# Altium schematic sheet Sheet2.SchDoc(Sheet2)
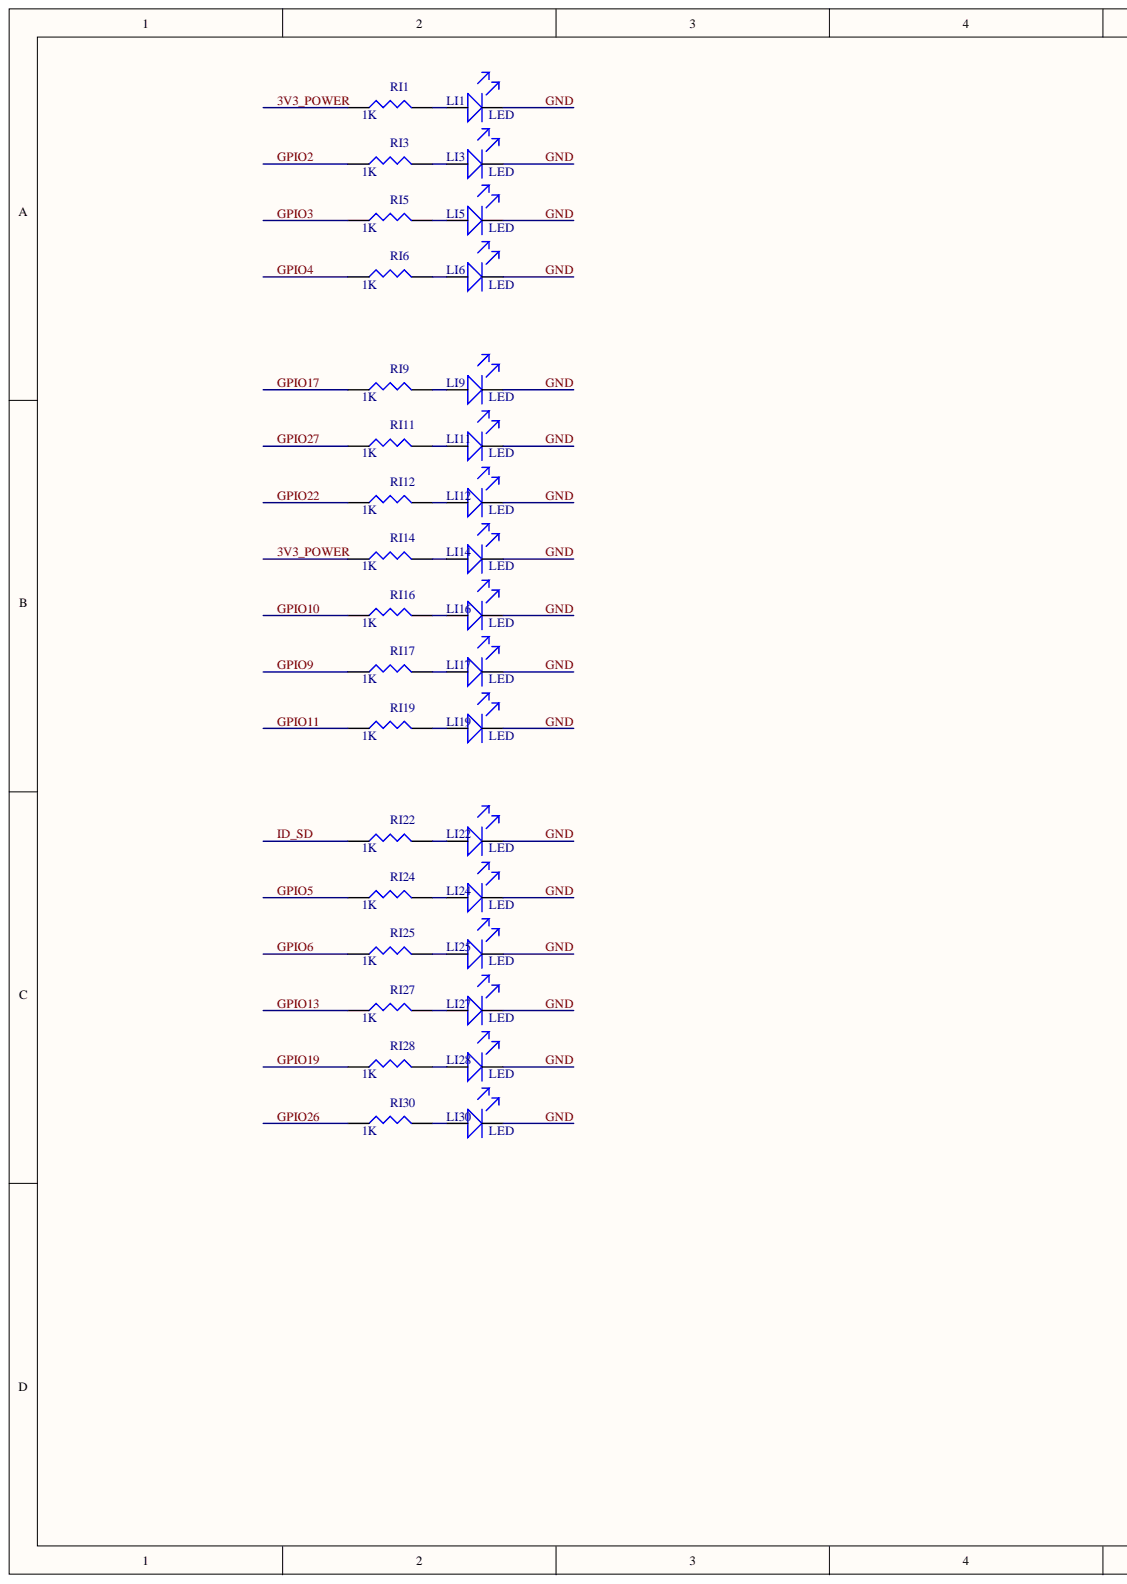
[diagram p0: Sheet2.SchDoc, left]
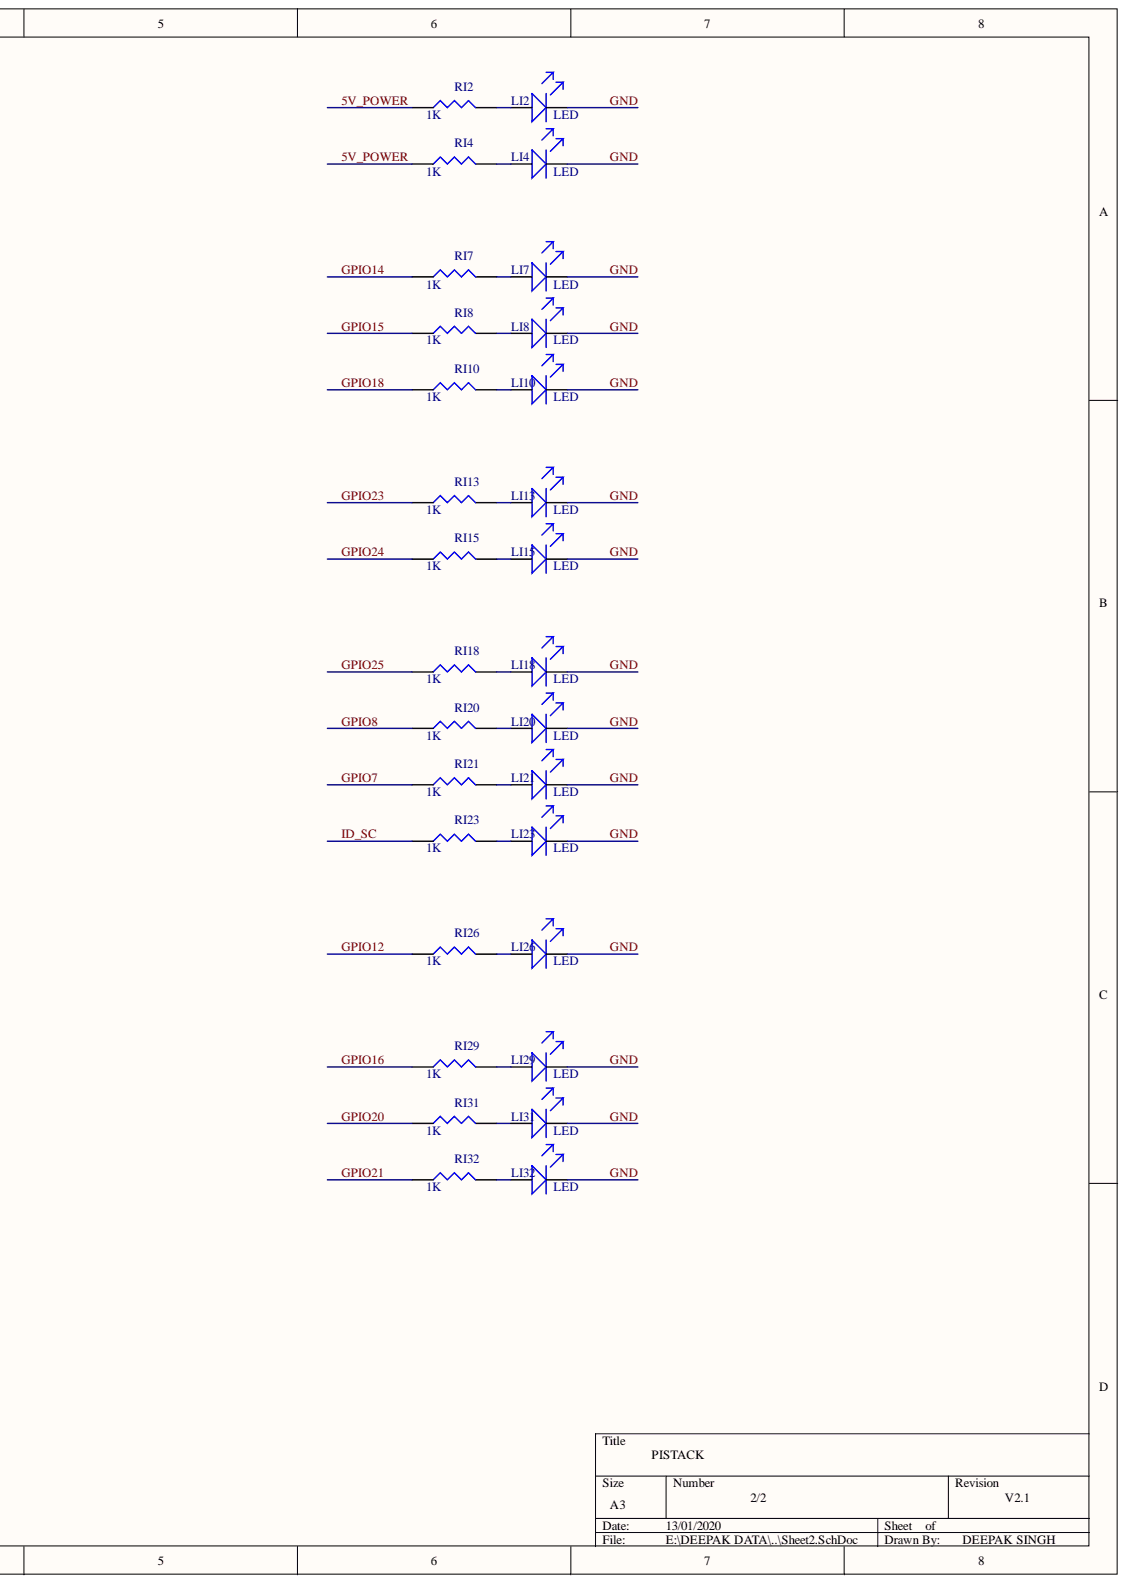
[diagram p1: Sheet2.SchDoc, right]

## Parts
LI1  LED  [LED_0603_neg]
LI2  LED  [LED_0603_neg]
LI3  LED  [LED_0603_neg]
LI4  LED  [LED_0603_neg]
LI5  LED  [LED_0603_neg]
LI6  LED  [LED_0603_neg]
LI7  LED  [LED_0603_neg]
LI8  LED  [LED_0603_neg]
LI9  LED  [LED_0603_neg]
LI10  LED  [LED_0603_neg]
LI11  LED  [LED_0603_neg]
LI12  LED  [LED_0603_neg]
LI13  LED  [LED_0603_neg]
LI14  LED  [LED_0603_neg]
LI15  LED  [LED_0603_neg]
LI16  LED  [LED_0603_neg]
LI17  LED  [LED_0603_neg]
LI18  LED  [LED_0603_neg]
LI19  LED  [LED_0603_neg]
LI20  LED  [LED_0603_neg]
LI21  LED  [LED_0603_neg]
LI22  LED  [LED_0603_neg]
LI23  LED  [LED_0603_neg]
LI24  LED  [LED_0603_neg]
LI25  LED  [LED_0603_neg]
LI26  LED  [LED_0603_neg]
LI27  LED  [LED_0603_neg]
LI28  LED  [LED_0603_neg]
LI29  LED  [LED_0603_neg]
LI30  LED  [LED_0603_neg]
LI31  LED  [LED_0603_neg]
LI32  LED  [LED_0603_neg]
RI1  1K  [0603]
RI2  1K  [0603]
RI3  1K  [0603]
RI4  1K  [0603]
RI5  1K  [0603]
RI6  1K  [0603]
RI7  1K  [0603]
RI8  1K  [0603]
RI9  1K  [0603]
RI10  1K  [0603]
RI11  1K  [0603]
RI12  1K  [0603]
RI13  1K  [0603]
RI14  1K  [0603]
RI15  1K  [0603]
RI16  1K  [0603]
RI17  1K  [0603]
RI18  1K  [0603]
RI19  1K  [0603]
RI20  1K  [0603]
RI21  1K  [0603]
RI22  1K  [0603]
RI23  1K  [0603]
RI24  1K  [0603]
RI25  1K  [0603]
RI26  1K  [0603]
RI27  1K  [0603]
RI28  1K  [0603]
RI29  1K  [0603]
RI30  1K  [0603]
RI31  1K  [0603]
RI32  1K  [0603]

## Nets
3V3_POWER: RI1.1 RI14.1
5V_POWER: RI2.1 RI4.1
GND: LI1.K LI2.K LI3.K LI4.K LI5.K LI6.K LI7.K LI8.K LI9.K LI10.K LI11.K LI12.K LI13.K LI14.K LI15.K LI16.K LI17.K LI18.K LI19.K LI20.K LI21.K LI22.K LI23.K LI24.K LI25.K LI26.K LI27.K LI28.K LI29.K LI30.K LI31.K LI32.K
GPIO2: RI3.1
GPIO3: RI5.1
GPIO4: RI6.1
GPIO5: RI24.1
GPIO6: RI25.1
GPIO7: RI21.1
GPIO8: RI20.1
GPIO9: RI17.1
GPIO10: RI16.1
GPIO11: RI19.1
GPIO12: RI26.1
GPIO13: RI27.1
GPIO14: RI7.1
GPIO15: RI8.1
GPIO16: RI29.1
GPIO17: RI9.1
GPIO18: RI10.1
GPIO19: RI28.1
GPIO20: RI31.1
GPIO21: RI32.1
GPIO22: RI12.1
GPIO23: RI13.1
GPIO24: RI15.1
GPIO25: RI18.1
GPIO26: RI30.1
GPIO27: RI11.1
ID_SC: RI23.1
ID_SD: RI22.1
NetLI1_A: LI1.A RI1.2
NetLI2_A: LI2.A RI2.2
NetLI3_A: LI3.A RI3.2
NetLI4_A: LI4.A RI4.2
NetLI5_A: LI5.A RI5.2
NetLI6_A: LI6.A RI6.2
NetLI7_A: LI7.A RI7.2
NetLI8_A: LI8.A RI8.2
NetLI9_A: LI9.A RI9.2
NetLI10_A: LI10.A RI10.2
NetLI11_A: LI11.A RI11.2
NetLI12_A: LI12.A RI12.2
NetLI13_A: LI13.A RI13.2
NetLI14_A: LI14.A RI14.2
NetLI15_A: LI15.A RI15.2
NetLI16_A: LI16.A RI16.2
NetLI17_A: LI17.A RI17.2
NetLI18_A: LI18.A RI18.2
NetLI19_A: LI19.A RI19.2
NetLI20_A: LI20.A RI20.2
NetLI21_A: LI21.A RI21.2
NetLI22_A: LI22.A RI22.2
NetLI23_A: LI23.A RI23.2
NetLI24_A: LI24.A RI24.2
NetLI25_A: LI25.A RI25.2
NetLI26_A: LI26.A RI26.2
NetLI27_A: LI27.A RI27.2
NetLI28_A: LI28.A RI28.2
NetLI29_A: LI29.A RI29.2
NetLI30_A: LI30.A RI30.2
NetLI31_A: LI31.A RI31.2
NetLI32_A: LI32.A RI32.2

## Library data of the parts
RI1-RI32 = RES: Component Kind: Standard; Library Name: dxp_pasl_lib.IntLib
LI1-LI32 = LED: Component Kind: Standard; Library Name: dxp_pasl_lib.IntLib
